# Altium schematic sheet LIDO-HW.100.VinAndPower.SchDoc(LIDO-HW.100.VinAndPower)
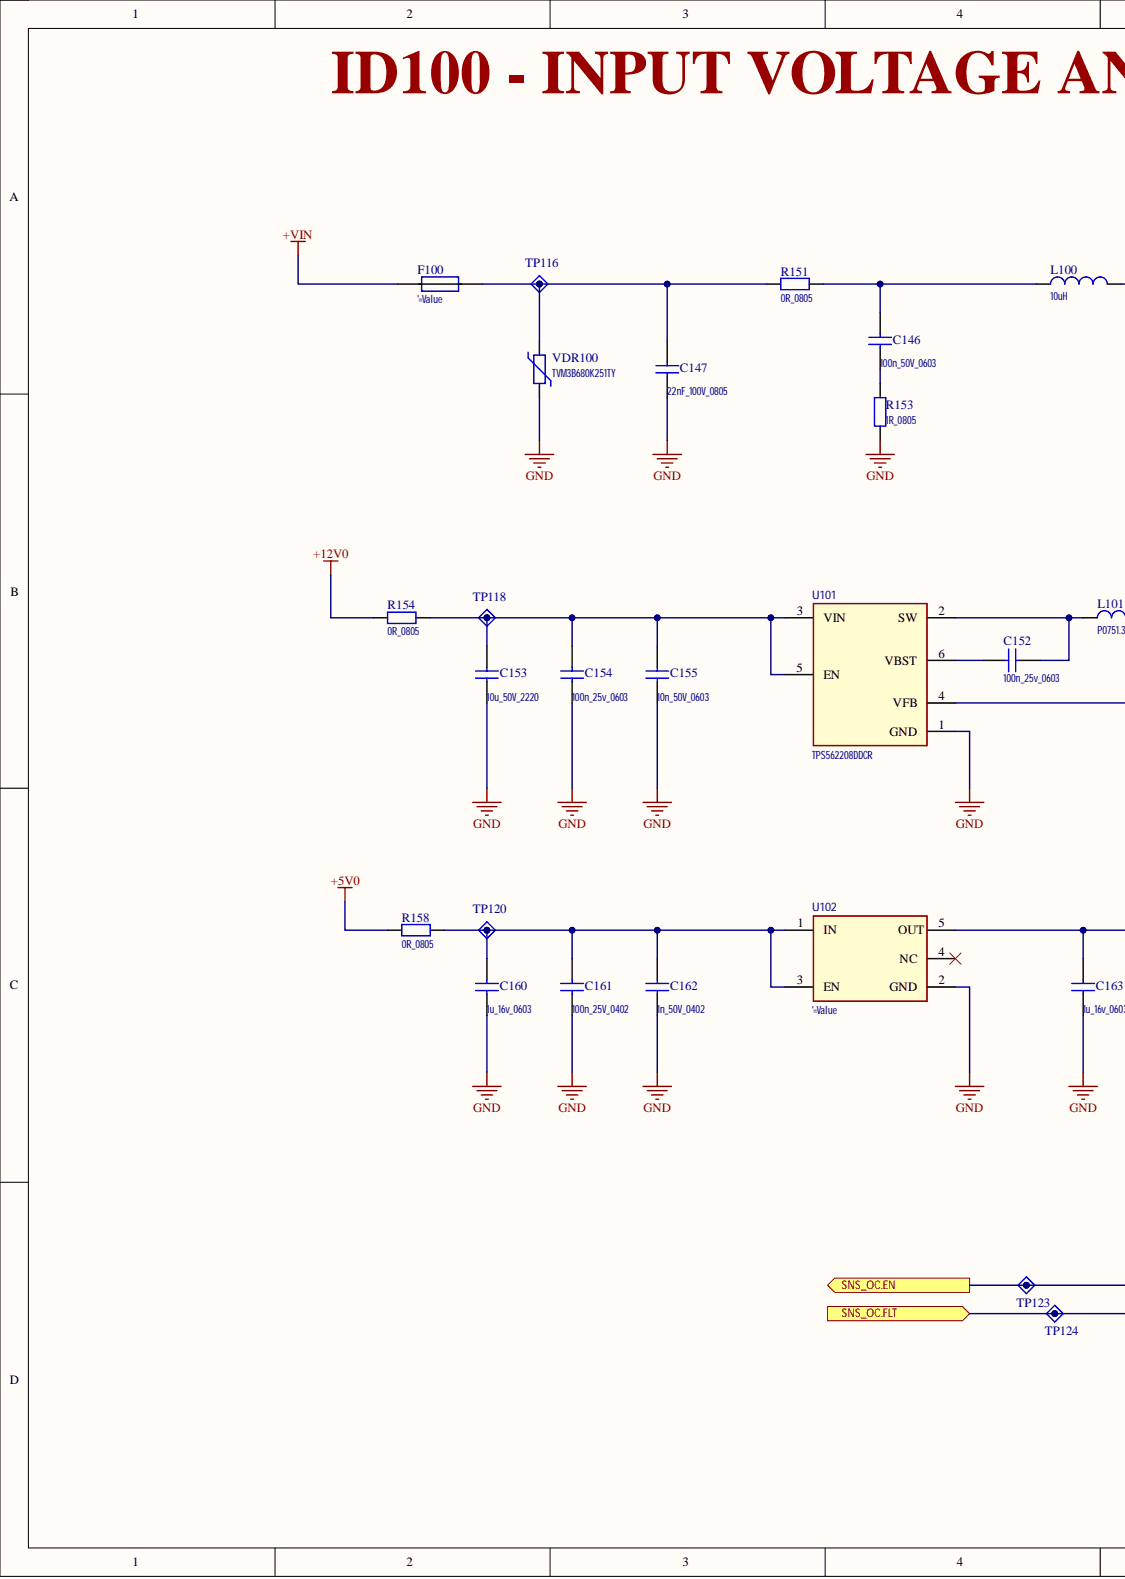
[diagram p0: LIDO-HW.100.VinAndPower.SchDoc, left]
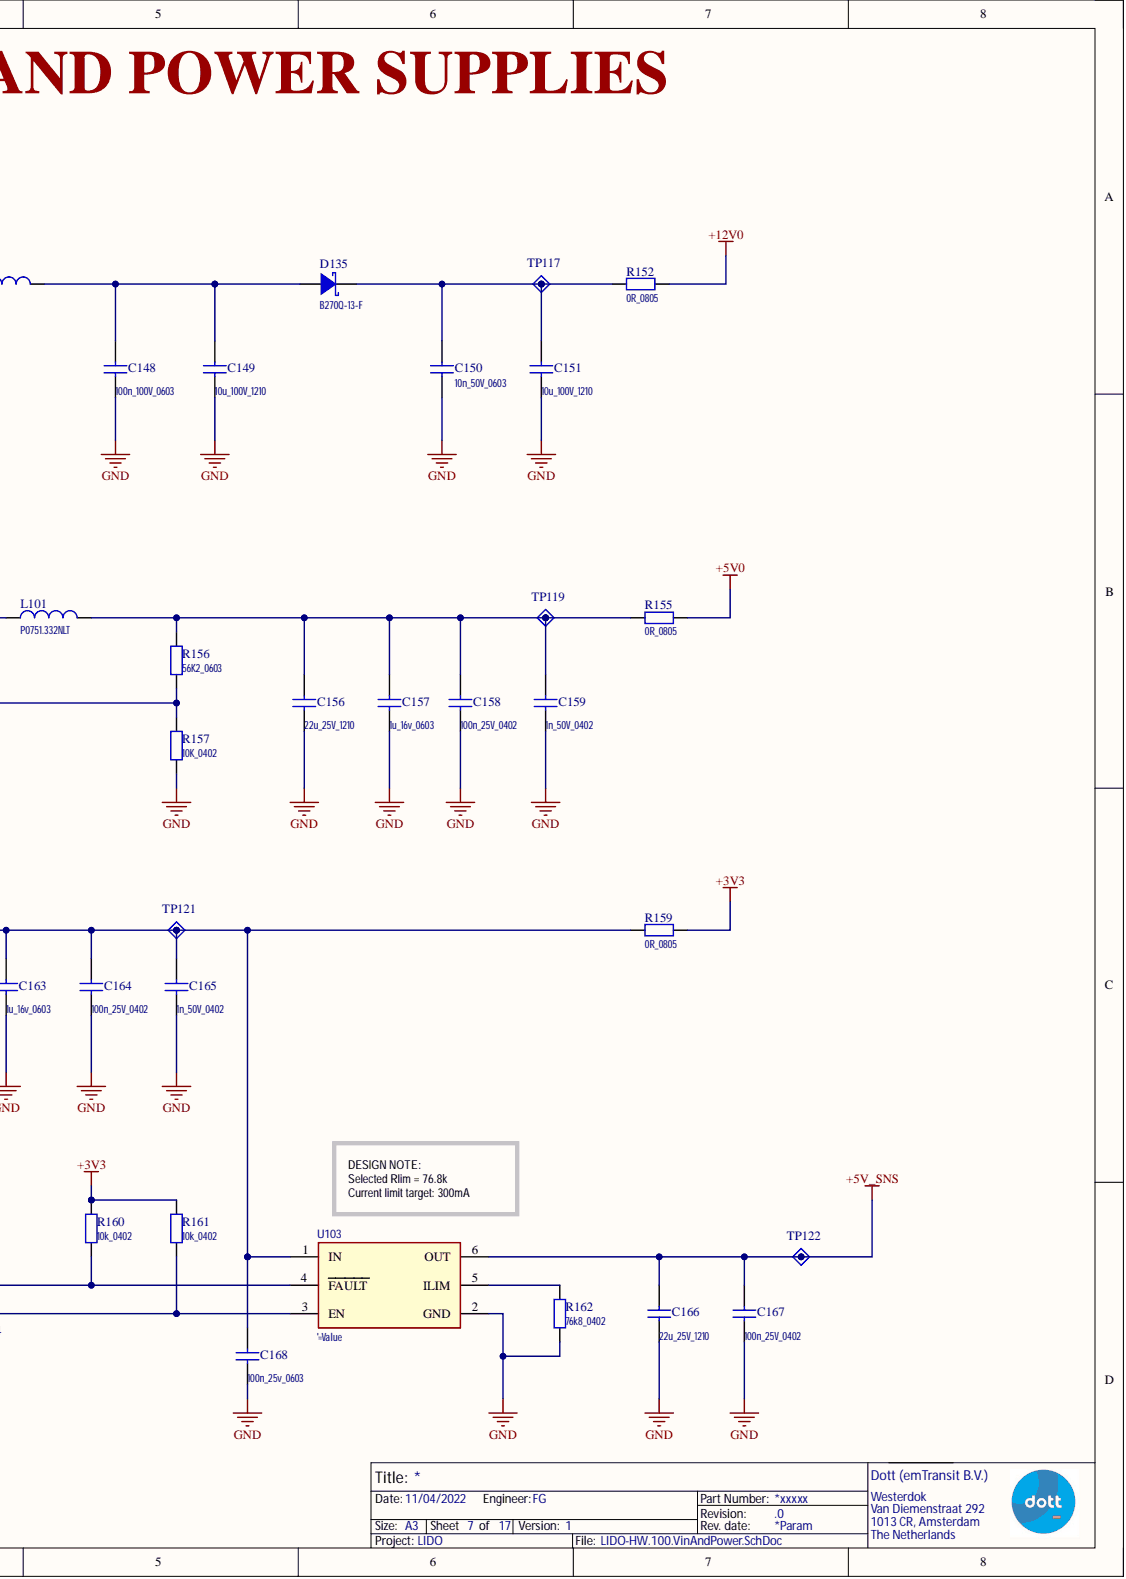
[diagram p1: LIDO-HW.100.VinAndPower.SchDoc, right]

## Parts
C146  100n_50V_0603
C147  22nF_100V_0805
C148  100n_100V_0603
C149  10u_100V_1210
C150  10n_50V_0603
C151  10u_100V_1210
C152  100n_25v_0603
C153  10u_50V_2220
C154  100n_25v_0603
C155  10n_50V_0603
C156  22u_25V_1210
C157  1u_16v_0603
C158  100n_25V_0402
C159  1n_50V_0402
C160  1u_16v_0603
C161  100n_25V_0402
C162  1n_50V_0402
C163  1u_16v_0603
C164  100n_25V_0402
C165  1n_50V_0402
C166  22u_25V_1210
C167  100n_25V_0402
C168  100n_25v_0603
D135  B270Q-13-F
F100  '=Value
L100  10uH
L101  P0751.332NLT
R151  0R_0805
R152  0R_0805
R153  1R_0805
R154  0R_0805
R155  0R_0805
R156  56K2_0603
R157  10K_0402
R158  0R_0805
R159  0R_0805
R160  10k_0402
R161  10k_0402
R162  76k8_0402
TP116  TestPoint_A  (value not drawn)  (no BOM)
TP117  TestPoint_A  (value not drawn)  (no BOM)
TP118  TestPoint_A  (value not drawn)  (no BOM)
TP119  TestPoint_A  (value not drawn)  (no BOM)
TP120  TestPoint_A  (value not drawn)  (no BOM)
TP121  TestPoint_A  (value not drawn)  (no BOM)
TP122  TestPoint_A  (value not drawn)  (no BOM)
TP123  TestPoint_A  (value not drawn)  (no BOM)
TP124  TestPoint_A  (value not drawn)  (no BOM)
U101  TPS562208DDCR
U102  '=Value
U103  '=Value
VDR100  TVM3B680K251TY

## Ports
SNS_OC.EN, SNS_OC.FLT

## Nets
+3V3: R159.1 R160.2 R161.2
+5V0: R155.1 R158.2
+5V_SNS: C166.1 C167.1 TP122.1 U103.6(OUT)
+12V0: R152.1 R154.2
+VIN: F100.1
GND: C147.2 C148.2 C149.2 C150.2 C151.2 C153.2 C154.2 C155.2 C156.2 C157.2 C158.2 C159.2 C160.2 C161.2 C162.2 C163.2 C164.2 C165.2 C166.2 C167.2 C168.2 R153.2 R157.2 R162.1 U101.1(GND) U102.2(GND) U103.2(GND) VDR100.1
SNS_OC.EN: R160.1 TP123.1 U103.4(FAULT) ; port SNS_OC.EN
SNS_OC.FLT: R161.1 TP124.1 U103.3(EN) ; port SNS_OC.FLT
NetC146_1: C146.1 L100.1 R151.1
NetC146_2: C146.2 R153.1
NetC147_1: C147.1 F100.2 R151.2 TP116.1 VDR100.2
NetC148_1: C148.1 C149.1 D135.A L100.2
NetC150_1: C150.1 C151.1 D135.C R152.2 TP117.1
NetC152_1: C152.1 U101.6(VBST)
NetC152_2: C152.2 L101.1 U101.2(SW)
NetC153_1: C153.1 C154.1 C155.1 R154.1 TP118.1 U101.3(VIN) U101.5(EN)
NetC156_1: C156.1 C157.1 C158.1 C159.1 L101.2 R155.2 R156.1 TP119.1
NetC160_1: C160.1 C161.1 C162.1 R158.1 TP120.1 U102.1(IN) U102.3(EN)
NetC163_1: C163.1 C164.1 C165.1 C168.1 R159.2 TP121.1 U102.5(OUT) U103.1(IN)
NetR156_2: R156.2 R157.1 U101.4(VFB)
NetR162_2: R162.2 U103.5(ILIM)

Not connected: U102 4(NC)

## Library data of the parts
C146-C168 = Ceramic: Ceramic CAP Symbol; Component Kind: Standard; Library Name: 01_Capacitors.SchLib
D135 = Schottky_A: Schottky Diode Symbol; Component Kind: Standard; Library Name: 06_Diodes.SchLib
F100 = FUSE: Fuse Symbol; Component Kind: Standard; Library Name: 04_Circuit_Protection.SchLib
L100 L101 = Inductor: Inductor Symbol; Component Kind: Standard; Library Name: 03_Inductors_Coils_Chokes.SchLib
R151-R162 = Resistor: Resistor Symbol; Component Kind: Standard; Library Name: 02_Resistors.SchLib
TP116-TP124 = TestPoint_A: Test Point Symbol verion A; 00_Name: TP_XXmm; 01_Diameter: 1mm; Library Name: 31_MFG_Elements.SchLib
U101 = TPS562208: TI  4.5-V to 17-V Input, 2-A Synchronous Step-Down Voltage Regulator  in 6-Pin SOT-23; Component Kind: Standard; Library Name: 15_IC_PMIC.SchLib
U102 = TLV74333PD: IC REG LINEAR 3.3V 300MA SOT23-5; Component Kind: Standard; Library Name: 15_IC_PMIC.SchLib
U103 = TPS2552_A: TI, Power Switch/Driver 1:1 N-Channel 1.5A SOT-23-6; Component Kind: Standard; Library Name: 15_IC_PMIC.SchLib
VDR100 = VARISTOR: Varistor Symbol; Component Kind: Standard; Library Name: 04_Circuit_Protection.SchLib
